# Altium schematic sheet 03_IO-CONNECTION.SchDoc
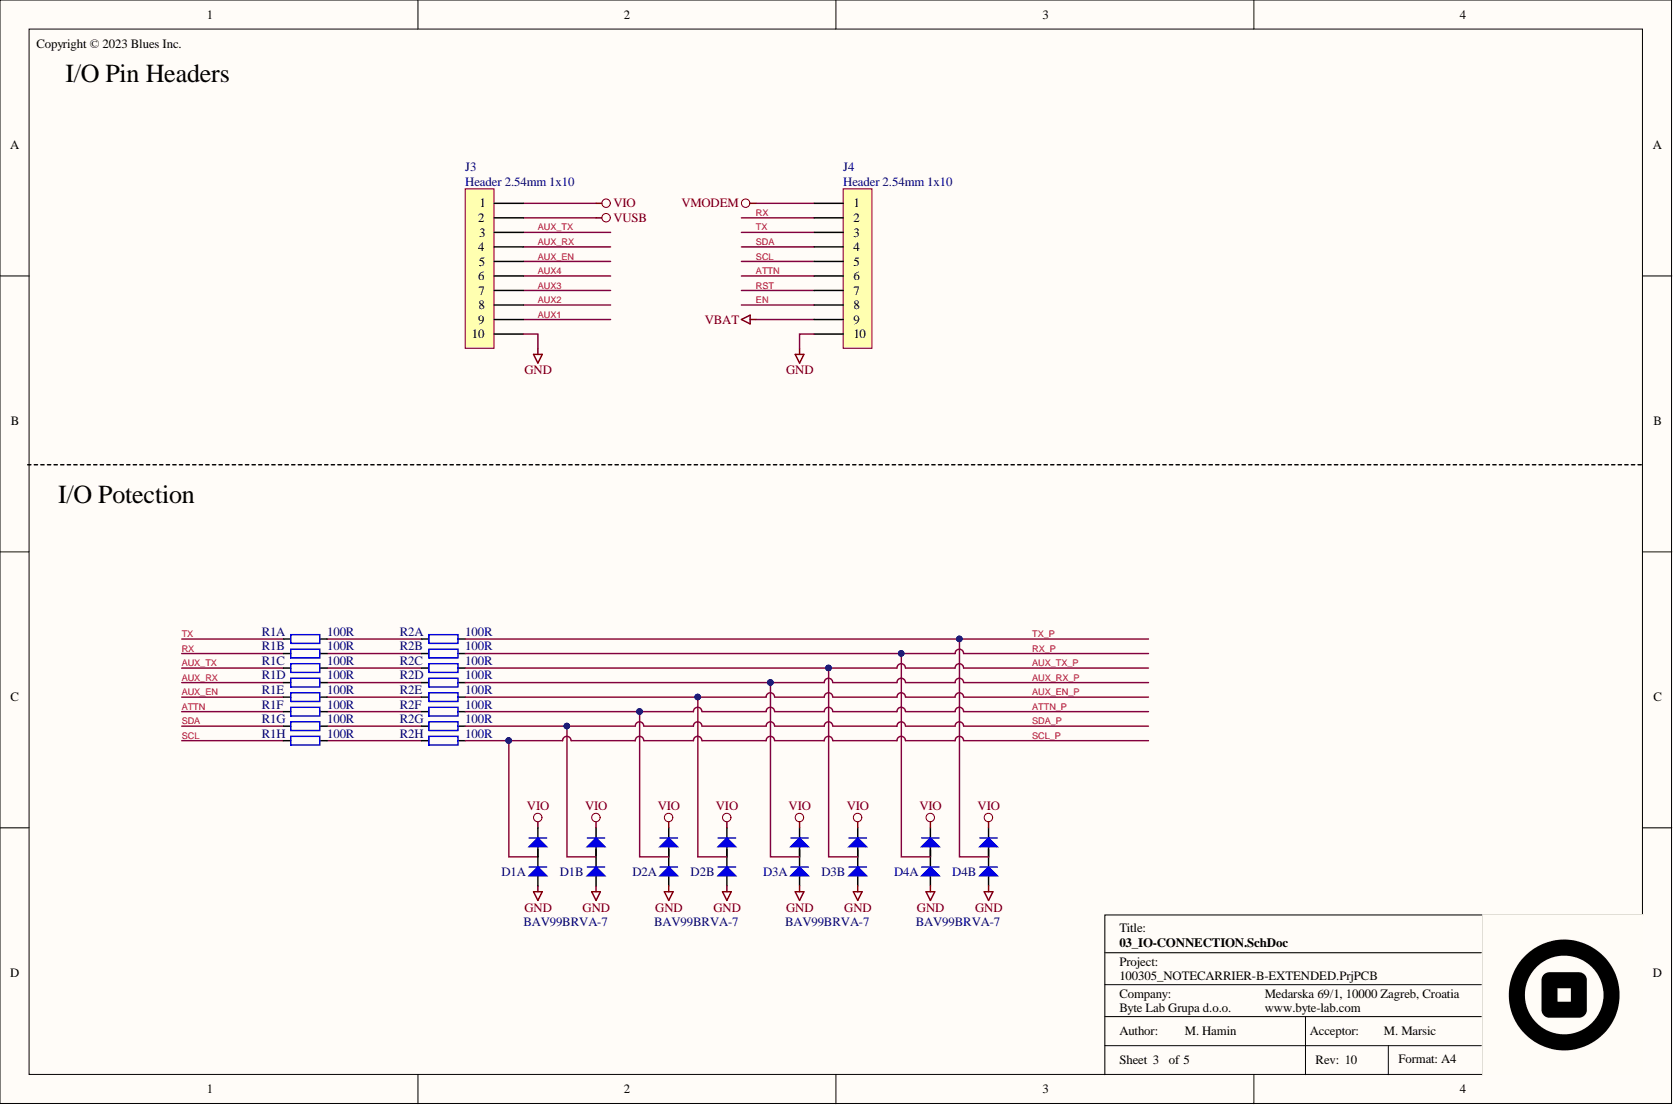
[diagram p0: 03_IO-CONNECTION.SchDoc]

## Parts
D1  BAV99BRVA-7  [SOT-563]  (parts A,B)
D2  BAV99BRVA-7  [SOT-563]  (parts A,B)
D3  BAV99BRVA-7  [SOT-563]  (parts A,B)
D4  BAV99BRVA-7  [SOT-563]  (parts A,B)
J3  Header 2.54mm 1x10  [Header 2.54mm 1X10]
J4  Header 2.54mm 1x10  [Header 2.54mm 1X10]
R1  100R  [RESCAXS80P640X160X50-16N]  (parts A,B,C,D,E,F,G,H)
R2  100R  [RESCAXS80P640X160X50-16N]  (parts A,B,C,D,E,F,G,H)

## Nets
GND: D1.1 D1.4 D2.1 D2.4 D3.1 D3.4 D4.1 D4.4 J3.10 J4.10
VBAT: J4.9
VIO: D1.2 D1.5 D2.2 D2.5 D3.2 D3.5 D4.2 D4.5 J3.1
VMODEM: J4.1
VUSB: J3.2
ATTN: J4.6 R1.6
ATTN_P: D2.6 R2.11
AUX1: J3.9
AUX2: J3.8
AUX3: J3.7
AUX4: J3.6
AUX_EN: J3.5 R1.5
AUX_EN_P: D2.3 R2.12
AUX_RX: J3.4 R1.4
AUX_RX_P: D3.6 R2.13
AUX_TX: J3.3 R1.3
AUX_TX_P: D3.3 R2.14
EN: J4.8
RST: J4.7
RX: J4.2 R1.2
RX_P: D4.6 R2.15
SCL: J4.5 R1.8
SCL_P: D1.6 R2.9
SDA: J4.4 R1.7
SDA_P: D1.3 R2.10
TX: J4.3 R1.1
TX_P: D4.3 R2.16
NetR1_9: R1.9 R2.8
NetR1_10: R1.10 R2.7
NetR1_11: R1.11 R2.6
NetR1_12: R1.12 R2.5
NetR1_13: R1.13 R2.4
NetR1_14: R1.14 R2.3
NetR1_15: R1.15 R2.2
NetR1_16: R1.16 R2.1

## Library data of the parts
D1-D4 = BAV99BRVA-7: DIODE ARRAY 75V 215MA SOT563; BL Part Number: 2007-657; Manufacturer: Diodes Incorporated; Drawn_By: Hamin; Component Kind: Standard; DateModified: 19-03-2023; Library Name: BL_Active.SchLib; Manufacturer Part Number: BAV99BRVA-7; Supplier 1: Digi-Key; Supplier Part Number 1: BAV99BRVA-7DICT-ND
J3 J4 = Conn Header 2.54mm 1X10 10129378-910002BLF: 2.54mm pitch 10 pin (1x10) top entry wire to board connector THT; BL Part Number: 2007-658; Manufacturer: Amphenol ICC (FCI); Drawn_By: Hamin; Component Kind: Standard; DateModified: 19-06-2023; Library Name: BL_Mechanical.SchLib; Manufacturer Part Number: 10129378-910002BLF; Supplier 1: Digi-Key; Supplier Part Number 1: 10129378-910002BLF-ND
R1 R2 = RESCAXS80P640X160X50-16N - 8x100R: Resistor array 100R 5% 2506; BL Part Number: 2007-472; Manufacturer: CTS Resistor Products; Manufacturer Part Number: 742C163101JP; Drawn_By: Hamin; Component Kind: Standard; DateModified: 08-05-2023; Library Name: BL_Passive.SchLib; Supplier 1: Digi-Key; Supplier Part Number 1: 742C163101JPCT-ND
